# Altium schematic sheet CN032-1 Industry EtherCAT Servo Controller_MCU.SchDoc
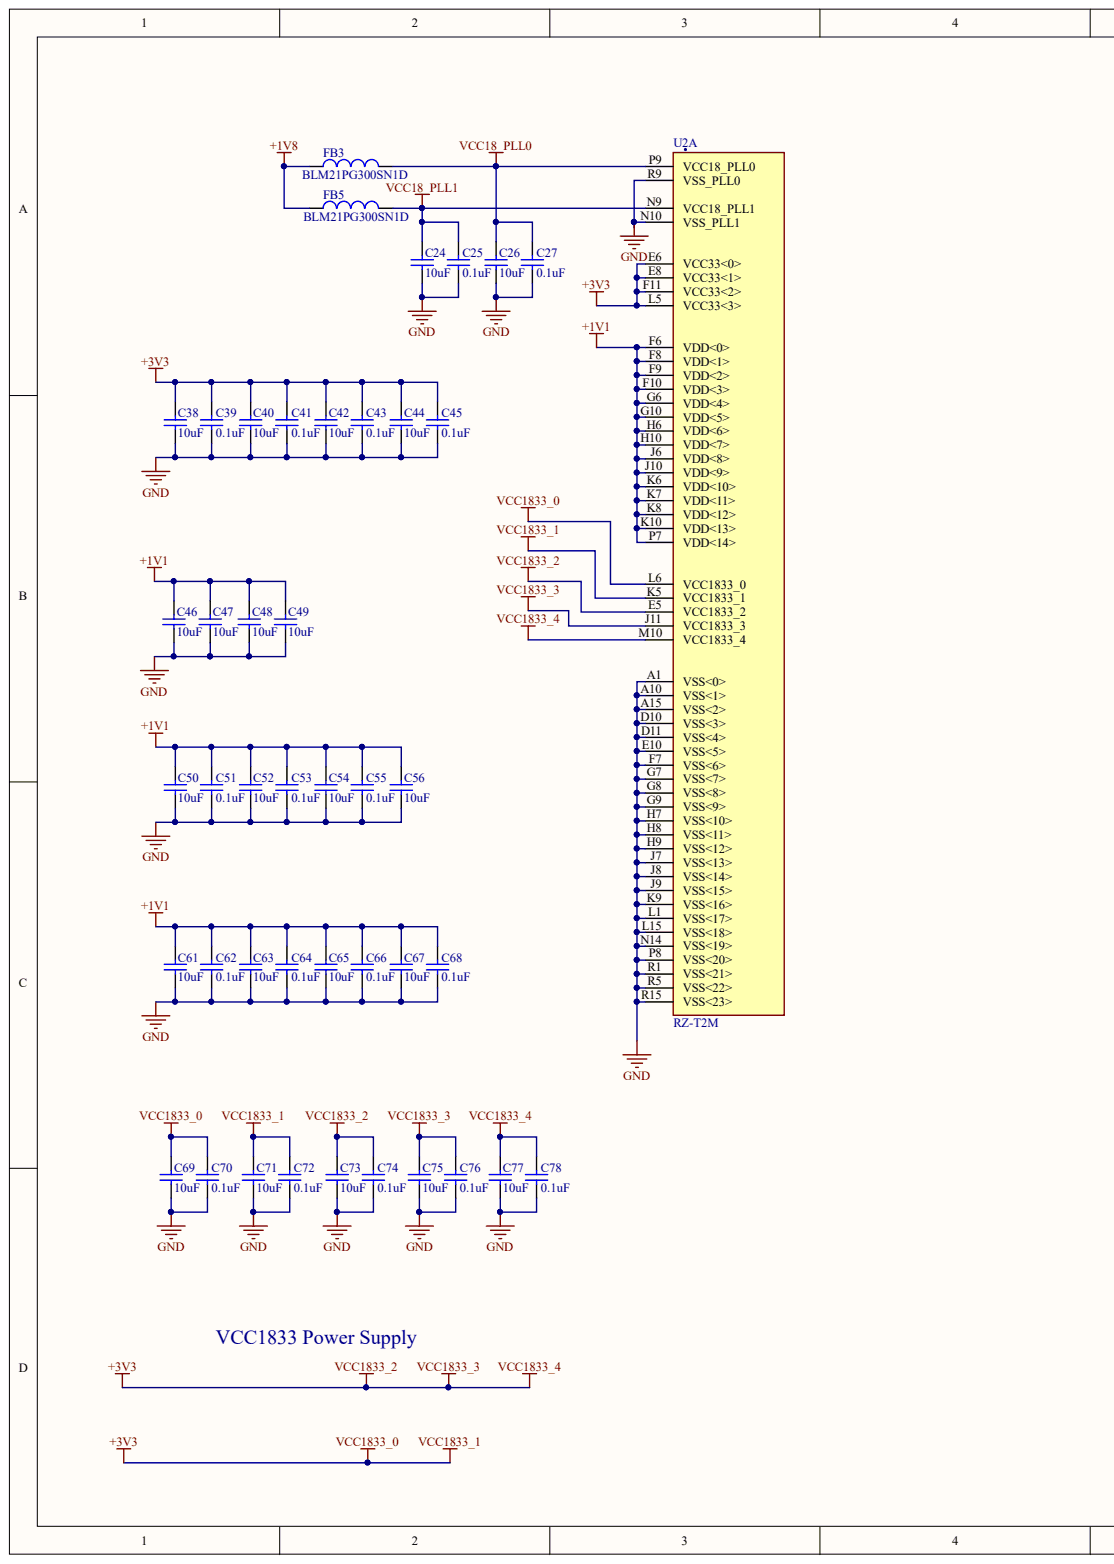
[diagram p0: CN032-1 Industry EtherCAT Servo Controller_MCU.SchDoc, left]
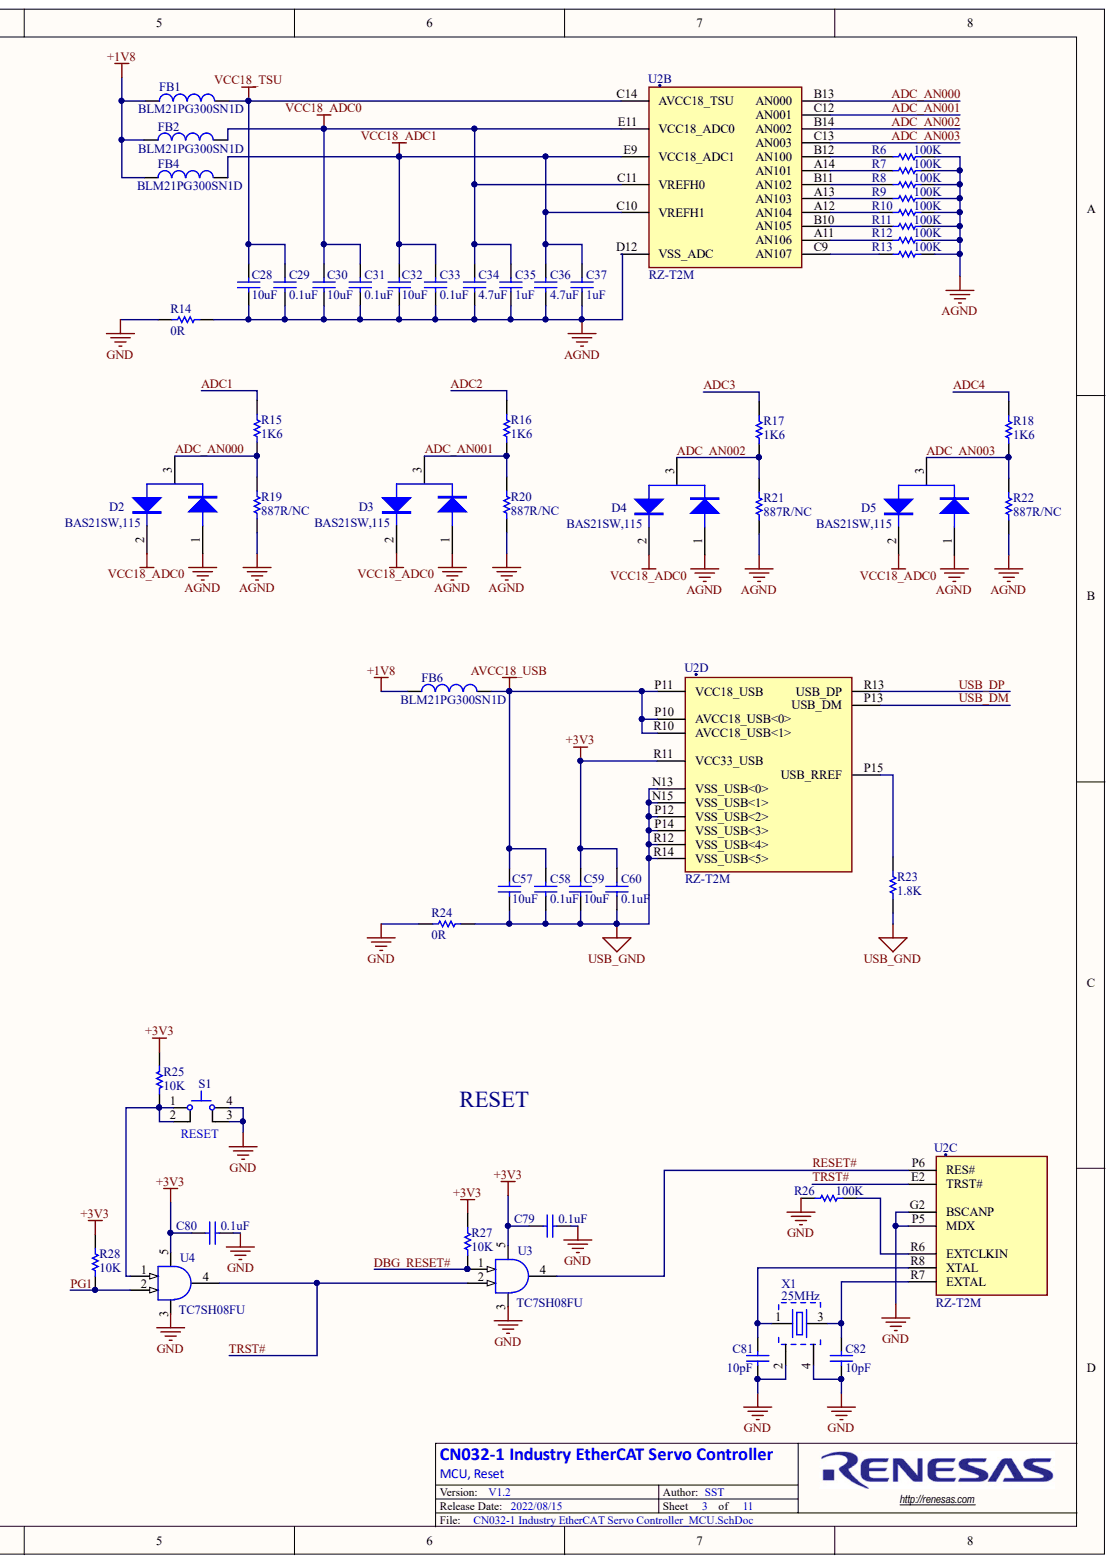
[diagram p1: CN032-1 Industry EtherCAT Servo Controller_MCU.SchDoc, right]

## Parts
C24  10uF  [CAPC0402-01]
C25  0.1uF  [CAPC0402-01]
C26  10uF  [CAPC0402-01]
C27  0.1uF  [CAPC0402-01]
C28  10uF  [CAPC0402-01]
C29  0.1uF  [CAPC0402-01]
C30  10uF  [CAPC0402-01]
C31  0.1uF  [CAPC0402-01]
C32  10uF  [CAPC0402-01]
C33  0.1uF  [CAPC0402-01]
C34  4.7uF  [CAPC0402-01]
C35  1uF  [CAPC0402-01]
C36  4.7uF  [CAPC0402-01]
C37  1uF  [CAPC0402-01]
C38  10uF  [CAPC0402-01]
C39  0.1uF  [CAPC0402-01]
C40  10uF  [CAPC0402-01]
C41  0.1uF  [CAPC0402-01]
C42  10uF  [CAPC0402-01]
C43  0.1uF  [CAPC0402-01]
C44  10uF  [CAPC0402-01]
C45  0.1uF  [CAPC0402-01]
C46  10uF  [CAPC0402-01]
C47  10uF  [CAPC0402-01]
C48  10uF  [CAPC0402-01]
C49  10uF  [CAPC0402-01]
C50  10uF  [CAPC0402-01]
C51  0.1uF  [CAPC0402-01]
C52  10uF  [CAPC0402-01]
C53  0.1uF  [CAPC0402-01]
C54  10uF  [CAPC0402-01]
C55  0.1uF  [CAPC0402-01]
C56  10uF  [CAPC0402-01]
C57  10uF  [CAPC0402-01]
C58  0.1uF  [CAPC0402-01]
C59  10uF  [CAPC0402-01]
C60  0.1uF  [CAPC0402-01]
C61  10uF  [CAPC0402-01]
C62  0.1uF  [CAPC0402-01]
C63  10uF  [CAPC0402-01]
C64  0.1uF  [CAPC0402-01]
C65  10uF  [CAPC0402-01]
C66  0.1uF  [CAPC0402-01]
C67  10uF  [CAPC0402-01]
C68  0.1uF  [CAPC0402-01]
C69  10uF  [CAPC0402-01]
C70  0.1uF  [CAPC0402-01]
C71  10uF  [CAPC0402-01]
C72  0.1uF  [CAPC0402-01]
C73  10uF  [CAPC0402-01]
C74  0.1uF  [CAPC0402-01]
C75  10uF  [CAPC0402-01]
C76  0.1uF  [CAPC0402-01]
C77  10uF  [CAPC0402-01]
C78  0.1uF  [CAPC0402-01]
C79  0.1uF  [CAPC0201-01]
C80  0.1uF  [CAPC0201-01]
C81  10pF  [CAPC0402-01]
C82  10pF  [CAPC0402-01]
D2  BAS21SW,115  [LC-SOT-323(SC-70)]
D3  BAS21SW,115  [LC-SOT-323(SC-70)]
D4  BAS21SW,115  [LC-SOT-323(SC-70)]
D5  BAS21SW,115  [LC-SOT-323(SC-70)]
FB1  BLM21PG300SN1D  [FB_0805]
FB2  BLM21PG300SN1D  [FB_0805]
FB3  BLM21PG300SN1D  [FB_0805]
FB4  BLM21PG300SN1D  [FB_0805]
FB5  BLM21PG300SN1D  [FB_0805]
FB6  BLM21PG300SN1D  [FB_0805]
R6  100K  [RESC0402-01]
R7  100K  [RESC0402-01]
R8  100K  [RESC0402-01]
R9  100K  [RESC0402-01]
R10  100K  [RESC0402-01]
R11  100K  [RESC0402-01]
R12  100K  [RESC0402-01]
R13  100K  [RESC0402-01]
R14  0R  [RESC0603-01]
R15  Resistance: 1K6  [RESC0402-01]  (hidden comment: 1.6K)
R16  Resistance: 1K6  [RESC0402-01]  (hidden comment: 1.6K)
R17  Resistance: 1K6  [RESC0402-01]  (hidden comment: 1.6K)
R18  Resistance: 1K6  [RESC0402-01]  (hidden comment: 1.6K)
R19  887R/NC  [RESC0402-01]
R20  887R/NC  [RESC0402-01]
R21  887R/NC  [RESC0402-01]
R22  887R/NC  [RESC0402-01]
R23  1.8K  [RESC0402-01]
R24  0R  [RESC0603-01]
R25  10K  [RESC0402-01]
R26  100K  [RESC0402-01]
R27  10K  [RESC0402-01]
R28  10K  [RESC0402-01]
S1  Switch 6x6mm  [SW_C&K_PTS645_43]  (value not drawn)
U2  RZ-T2M  [RZ-N2L_BGA225]  (parts A,B,C,D)
U3  TC7SH08FU  [SC-70-5]
U4  TC7SH08FU  [SC-70-5]
X1  25MHz  [TXC_7V]

## Nets
+1V1: C46.2 C47.2 C48.2 C49.2 C50.2 C51.2 C52.2 C53.2 C54.2 C55.2 C56.2 C61.2 C62.2 C63.2 C64.2 C65.2 C66.2 C67.2 C68.2 U2.F6(VDD<0>) U2.F8(VDD<1>) U2.F9(VDD<2>) U2.F10(VDD<3>) U2.G6(VDD<4>) U2.G10(VDD<5>) U2.H6(VDD<6>) U2.H10(VDD<7>) U2.J6(VDD<8>) U2.J10(VDD<9>) U2.K6(VDD<10>) U2.K7(VDD<11>) U2.K8(VDD<12>) U2.K10(VDD<13>) U2.P7(VDD<14>)
+1V8: FB1.1 FB2.1 FB3.1 FB4.1 FB5.1 FB6.1
+3V3: C38.2 C39.2 C40.2 C41.2 C42.2 C43.2 C44.2 C45.2 C59.2 C60.2 C69.2 C70.2 C71.2 C72.2 C73.2 C74.2 C75.2 C76.2 C77.2 C78.2 C79.2 C80.2 R25.1 R27.1 R28.2 U2.E5(VCC1833_2) U2.E6(VCC33<0>) U2.E8(VCC33<1>) U2.F11(VCC33<2>) U2.J11(VCC1833_3) U2.K5(VCC1833_1) U2.L5(VCC33<3>) U2.L6(VCC1833_0) U2.M10(VCC1833_4) U2.R11(VCC33_USB) U3.5 U4.5
AGND: C28.1 C29.1 C30.1 C31.1 C32.1 C33.1 C34.1 C35.1 C36.1 C37.1 D2.1 D3.1 D4.1 D5.1 R6.2 R7.2 R8.2 R9.2 R10.2 R11.2 R12.2 R13.2 R14.2 R19.1 R20.1 R21.1 R22.1 U2.D12(VSS_ADC)
AVCC18_USB: C57.2 C58.2 FB6.2 U2.P10(AVCC18_USB<0>) U2.P11(VCC18_USB) U2.R10(AVCC18_USB<1>)
GND: C24.1 C25.1 C26.1 C27.1 C38.1 C39.1 C40.1 C41.1 C42.1 C43.1 C44.1 C45.1 C46.1 C47.1 C48.1 C49.1 C50.1 C51.1 C52.1 C53.1 C54.1 C55.1 C56.1 C61.1 C62.1 C63.1 C64.1 C65.1 C66.1 C67.1 C68.1 C69.1 C70.1 C71.1 C72.1 C73.1 C74.1 C75.1 C76.1 C77.1 C78.1 C79.1 C80.1 C81.1 C82.1 R14.1 R24.1 R26.1 S1.3 S1.4 U2.A1(VSS<0>) U2.A10(VSS<1>) U2.A15(VSS<2>) U2.D10(VSS<3>) U2.D11(VSS<4>) U2.E10(VSS<5>) U2.F7(VSS<6>) U2.G2(BSCANP) U2.G7(VSS<7>) U2.G8(VSS<8>) U2.G9(VSS<9>) U2.H7(VSS<10>) U2.H8(VSS<11>) U2.H9(VSS<12>) U2.J7(VSS<13>) U2.J8(VSS<14>) U2.J9(VSS<15>) U2.K9(VSS<16>) U2.L1(VSS<17>) U2.L15(VSS<18>) U2.N10(VSS_PLL1) U2.N14(VSS<19>) U2.P5(MDX) U2.P8(VSS<20>) U2.R1(VSS<21>) U2.R5(VSS<22>) U2.R9(VSS_PLL0) U2.R15(VSS<23>) U3.3 U4.3 X1.2 X1.4
USB_GND: C57.1 C58.1 C59.1 C60.1 R23.1 R24.2 U2.N13(VSS_USB<0>) U2.N15(VSS_USB<1>) U2.P12(VSS_USB<2>) U2.P14(VSS_USB<3>) U2.R12(VSS_USB<4>) U2.R14(VSS_USB<5>)
VCC18_ADC0: C30.2 C31.2 C34.2 C35.2 D2.2 D3.2 D4.2 D5.2 FB2.2 U2.C11(VREFH0) U2.E11(VCC18_ADC0)
VCC18_ADC1: C32.2 C33.2 C36.2 C37.2 FB4.2 U2.C10(VREFH1) U2.E9(VCC18_ADC1)
VCC18_PLL0: C26.2 C27.2 FB3.2 U2.P9(VCC18_PLL0)
VCC18_PLL1: C24.2 C25.2 FB5.2 U2.N9(VCC18_PLL1)
VCC18_TSU: C28.2 C29.2 FB1.2 U2.C14(AVCC18_TSU)
ADC1: R15.2
ADC2: R16.2
ADC3: R17.2
ADC4: R18.2
ADC_AN000: D2.3 R15.1 R19.2 U2.B13(AN000)
ADC_AN001: D3.3 R16.1 R20.2 U2.C12(AN001)
ADC_AN002: D4.3 R17.1 R21.2 U2.B14(AN002)
ADC_AN003: D5.3 R18.1 R22.2 U2.C13(AN003)
DBG_RESET#: R27.2 U3.1
PG1: R28.1 U4.2
RESET#: U2.P6(RES#) U3.4
TRST#: U2.E2(TRST#) U3.2 U4.4
USB_DM: U2.P13(USB_DM)
USB_DP: U2.R13(USB_DP)
NetC81_2: C81.2 U2.R8(XTAL) X1.1
NetC82_2: C82.2 U2.R7(EXTAL) X1.3
NetR6_1: R6.1 U2.B12(AN100)
NetR7_1: R7.1 U2.A14(AN101)
NetR8_1: R8.1 U2.B11(AN102)
NetR9_1: R9.1 U2.A13(AN103)
NetR10_1: R10.1 U2.A12(AN104)
NetR11_1: R11.1 U2.B10(AN105)
NetR12_1: R12.1 U2.A11(AN106)
NetR13_1: R13.1 U2.C9(AN107)
NetR23_2: R23.2 U2.P15(USB_RREF)
NetR25_2: R25.2 S1.1 S1.2 U4.1
NetR26_2: R26.2 U2.R6(EXTCLKIN)

## Library data of the parts
C24 C26 C28 C30 C32 C38 C40 C42 C44 C46-C50 C52 C54 C56 C57 C59 C61 C63 C65 C67 C69 C71 C73 C75 C77 = CAP-0402-10uF: Ceramic Chip Capacitor 0402 10uF 6.3V; Manufacturer: YAGEO; Mfg Part Number: CC0402MRX5R5BB106; Tolerance: 20%; Capacitance: 10uF; Component Kind: Standard; Package: 0402; Voltage: 6.3V; Library Name: Synergy.SchLib
C25 C27 C29 C31 C33 C39 C41 C43 C45 C51 C53 C55 C58 C60 C62 C64 C66 C68 C70 C72 C74 C76 C78 = CAP-0402-0.1uF-YAGEO: Ceramic Chip Capacitor 0402 0.1uF 16V; Manufacturer: YAGEO; Mfg Part Number: CC0402KPX7R7BB104; Dialectric: X7R; Tolerance: 10%; Capacitance: 0.1uF; Component Kind: Standard; Package: 0402; Voltage: 16V; Library Name: Synergy.SchLib
C34 C36 = CAP-0402-4.7uF-YAGEO: Ceramic Chip Capacitor 0402 4.7uF 6.3V; Manufacturer: YAGEO; Mfg Part Number: CC0402MRX5R5BB475; Dialectric: X5R; Tolerance: 20%; Capacitance: 4.7uF; Component Kind: Standard; Package: 0402; Voltage: 6.3V; Library Name: Synergy.SchLib
C35 C37 = CAP-0402-1uF: Ceramic Chip Capacitor 0402 1uF 16V; Manufacturer: YAGEO; Mfg Part Number: CC0402KRX5R7BB105; Dialectric: X5R; Tolerance: 10%; Capacitance: 1uF; Component Kind: Standard; Package: 0402; Voltage: 16V; Library Name: Synergy.SchLib
C79 C80 = CAP-0201-0.1uF: Ceramic Chip Capacitor 0201 0.1uF 16V; Manufacturer: YAGEO; Mfg Part Number: CC0201KRX5R7BB104; Tolerance: 20%; Capacitance: 0.1uF; Component Kind: Standard; Package: 0201; Voltage: 16V; Library Name: CN268 IEEE-1588.SchLib
C81 C82 = CAP-0402-10pF: Ceramic Chip Capacitor 0402 10pF 50V; Manufacturer: YAGEO; Mfg Part Number: CC0402JRNPO9BN100; Dialectric: C0G; Tolerance: ±0.5pF; Capacitance: 10pF; Component Kind: Standard; Package: 0402; Voltage: 50V; Library Name: Synergy.SchLib
D2-D5 = DIO-BAS21SW: Diode Switching 250V 0.225A 3-Pin SC-70 T/R; Manufacturer: Nexperia USA Inc.; Component Kind: Standard; Display Name: BAS21SW,115; Mfg Part Number: BAS21SW,115; Package: SOT-323; Library Name: Synergy.SchLib
FB1-FB6 = FB-BLM21PG300SN1D: FERRITE BEAD 30 OHM 0805 1LN; Manufacturer: Murata; Current: 4A; Resistance: 14mΩ; Component Kind: Standard; Mfg Part Number: BLM21PG300SN1D; Package: 0805; Library Name: Synergy.SchLib
R6-R13 R26 = RES-0402-100K: Chip Resistor Thick Film 0402 100K 1% 1/16W; Manufacturer: YAGEO; Mfg Part Number: RC0402FR-07100KL; Component Kind: Standard; Package: 0402; Power: 1/16W; Resistance: 100K; Tolerance: 1%; Library Name: Synergy.SchLib
R14 R24 = RES-0603-0R: Chip Resistor Thick Film 0603 0R 1% 1/10W; Manufacturer: YAGEO; Mfg Part Number: RC0603FR-070RL; Component Kind: Standard; Package: 0603; Power: 1/10W; Resistance: 0R; Tolerance: 1%; Library Name: Synergy.SchLib
R15-R18 = RES-0402-1.6K: Chip Resistor Thick Film 0402 1K6 1% 1/16W; Manufacturer: YAGEO; Mfg Part Number: RC0402FR-071K6L; Component Kind: Standard; Package: 0402; Power: 1/16W; Tolerance: 1%; Library Name: Synergy.IntLib
R19-R22 = RES-0402-887R: Chip Resistor Thick Film 0402 887R 1% 1/16W; Manufacturer: YAGEO; Mfg Part Number: RC0402FR-07887RL; Component Kind: Standard; Package: 0402; Power: 1/16W; Resistance: 887R/NC; Tolerance: 1%; Library Name: Synergy.IntLib
R23 = RES-0402-1.8K: Chip Resistor Thick Film 0402 1.8K 1% 1/16W; Manufacturer: YAGEO; Mfg Part Number: RC0402FR-071K8L; Component Kind: Standard; Package: 0402; Power: 1/16W; Resistance: 1.8K; Tolerance: 1%; Library Name: Synergy.SchLib
R25 R27 R28 = RES-0402-10K: Chip Resistor Thick Film 0402 10K 1% 1/16W; Manufacturer: YAGEO; Mfg Part Number: RC0402FR-0710KL; Component Kind: Standard; Package: 0402; Power: 1/16W; Resistance: 10K; Tolerance: 1%
  R25 R27: Library Name: CN268 IEEE-1588.SchLib
  R28: Library Name: Synergy.SchLib
S1 = SWT-6x6mm: Push Button Switch 6 x 6mm 4.3mm High; Manufacturer: C&K; Mfg Part Number: PTS645SM43SMTR92 LFS; Component Kind: Standard; Library Name: CN268 IEEE-1588.SchLib
U2 = MCU-RZ-N2L: Renesas RZ-T2M MCU; Component Kind: Standard; Display Name: RZ-T2M; Manufacturer: Renesas; Library Name: Synergy.SchLib
U3 U4 = ICN-TC7SH08FU: IC GATE AND 1CH 2-INP USV; Manufacturer: Toshiba; Component Kind: Standard; Display Name: TC7SH08FU; Mfg Part Number: TC7SH08FU; Package: SC-70-5; Library Name: CN268 IEEE-1588.SCHLIB
X1 = XTL-25MHz 3225 15ppm: CRYSTAL 25.0000MHZ 10PF 3225-4 pads,SMD; Manufacturer: TXC; Mfg Part Number: 7M25070073; Freq Stability: +/-15ppm; Component Kind: Standard; Frequency: 25MHz; Load Capacitance: 10pF; Library Name: Synergy.SchLib
